# Altium schematic sheet CAN_SNIFFER.SchDoc
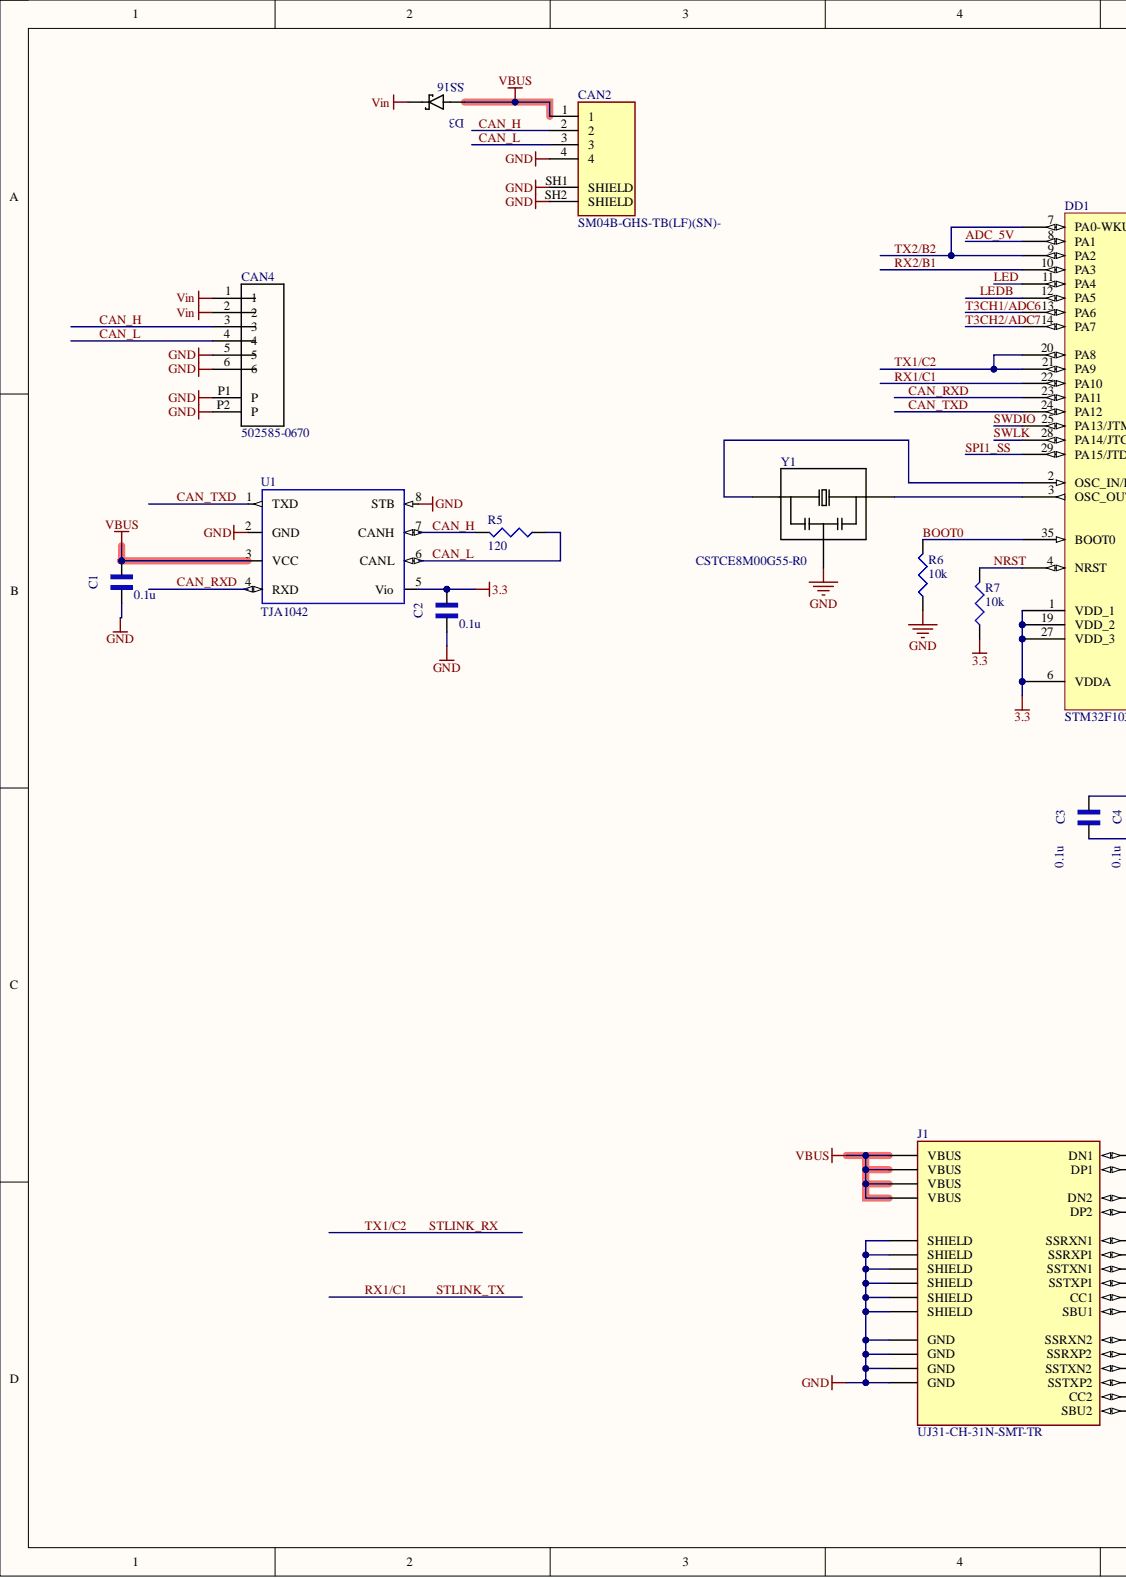
[diagram p0: CAN_SNIFFER.SchDoc, left]
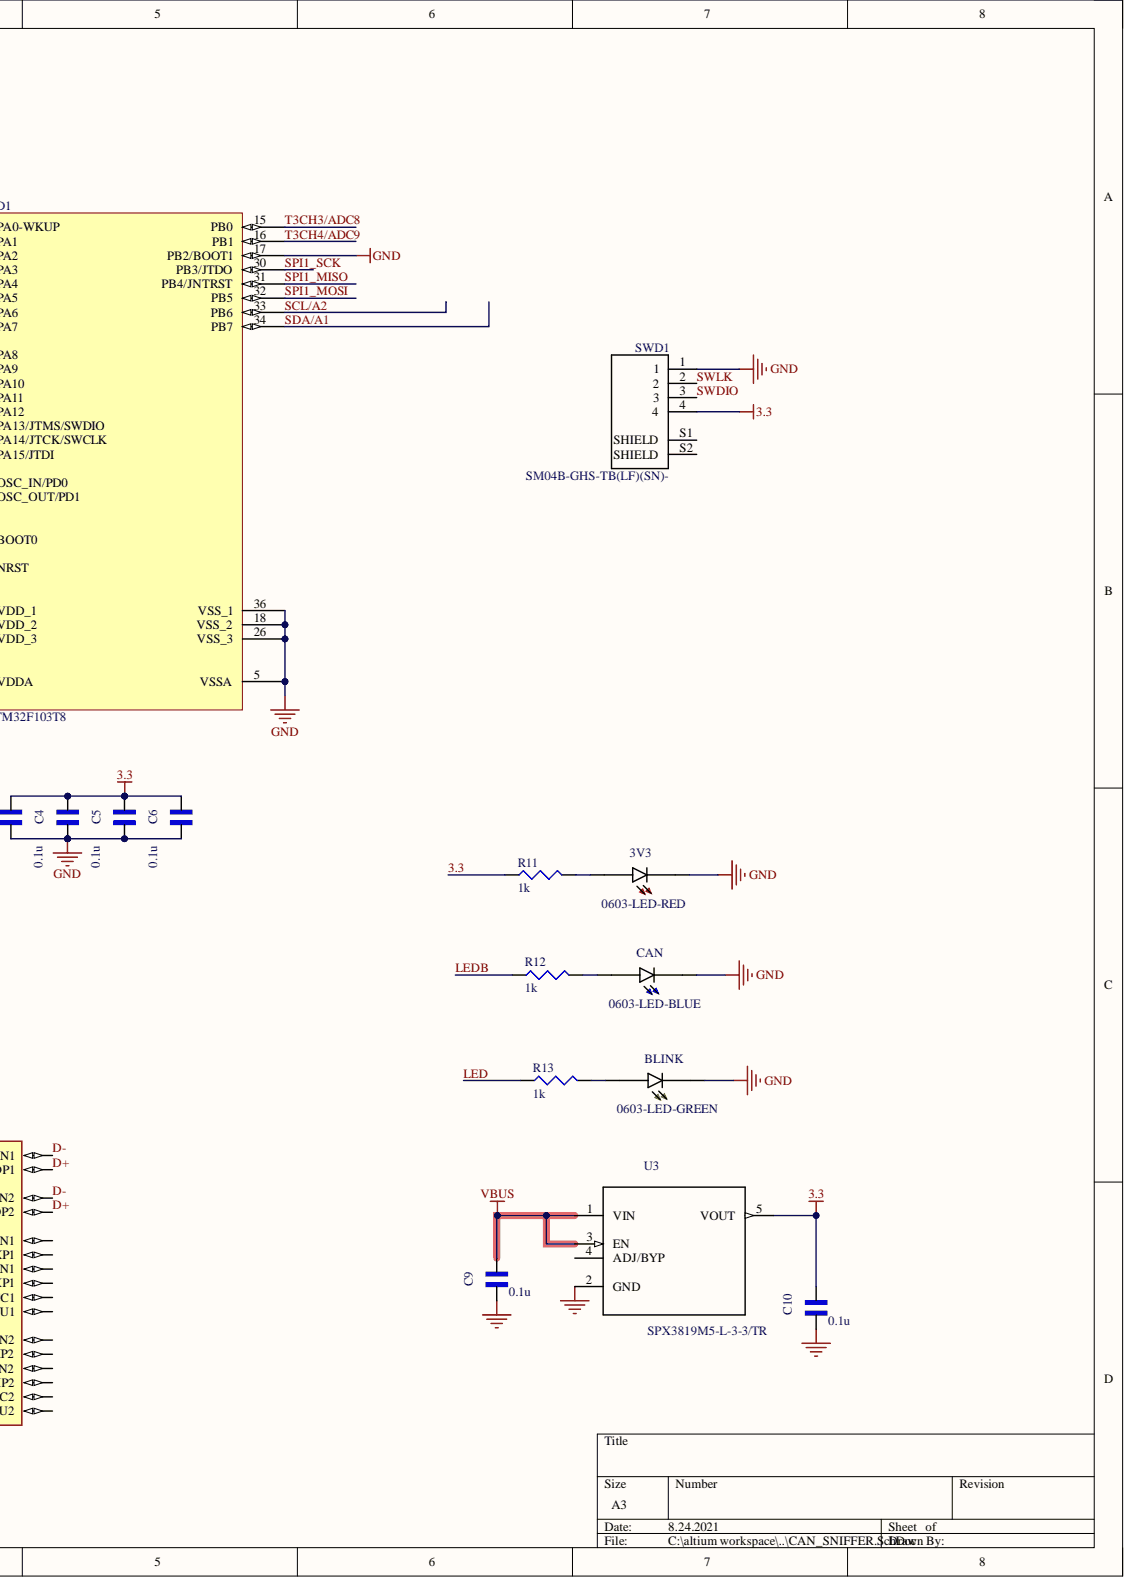
[diagram p1: CAN_SNIFFER.SchDoc, right]

## Parts
3V3  0603-LED-RED  [*LEDM1608X80_LTE-C1906R-14(Primary)]
BLINK  0603-LED-GREEN  [*LEDM1608X80_LTE-C1906R-14(Primary)]
C1  value 0.1u  [*CAPC1608X90]  (hidden comment: GX035C104MAT2-500)
C2  value 0.1u  [*CAPC1608X90]  (hidden comment: GX035C104MAT2-500)
C3  value 0.1u  [*CAPC1608X90]  (hidden comment: GX035C104MAT2-500)
C4  value 0.1u  [*CAPC1608X90]  (hidden comment: GX035C104MAT2-500)
C5  value 0.1u  [*CAPC1608X90]  (hidden comment: GX035C104MAT2-500)
C6  value 0.1u  [*CAPC1608X90]  (hidden comment: GX035C104MAT2-500)
C9  value 0.1u  [*CAPC1608X90]  (hidden comment: GX035C104MAT2-500)
C10  value 0.1u  [*CAPC1608X90]  (hidden comment: GX035C104MAT2-500)
CAN  0603-LED-BLUE  [*LEDM1608X80_LTE-C1906R-14(Primary)]
CAN2  SM04B-GHS-TB(LF)(SN)-  [JST_SM04B-GHS-TB(LF)(SN)-]
CAN4  502585-0670  [MOLEX_502585-0670]
D3  SS16  [*DIOM5227X250N_SS16(Primary)]
DD1  STM32F103T8  [*QFN50P600X600X100-37N]
J1  UJ31-CH-31N-SMT-TR  [CUI_UJ31-CH-31N-SMT-TR]
R5  value 120  [J1-0603]  (hidden comment: RC0603FR-07120RL)
R6  value 10k  [J1-0603]  (hidden comment: RC0603FR-0710KL)
R7  value 10k  [J1-0603]  (hidden comment: RC0603FR-0710KL)
R11  value 1k  [J1-0603]  (hidden comment: RC0603FR-101KL)
R12  value 1k  [J1-0603]  (hidden comment: RC0603FR-101KL)
R13  value 1k  [J1-0603]  (hidden comment: RC0603FR-101KL)
SWD1  SM04B-GHS-TB(LF)(SN)-  [JST_BM04B-GHS-holes]
U1  TJA1042  [SOT782-1]
U3  SPX3819M5-L-3-3/TR  [*SOT95P280X145-5N]
Y1  CSTCE8M00G55-R0  [*OSC_CSTCE8M00G55-R0]

## Nets
GND: 3V3.C BLINK.C C1.2 C2.2 C3.2 C4.2 C5.2 C6.2 C9.2 C10.2 CAN.C CAN2.4 CAN2.SH1(SHIELD) CAN2.SH2(SHIELD) CAN4.5 CAN4.6 CAN4.P1(P) CAN4.P2(P) DD1.5(VSSA) DD1.17(PB2/BOOT1) DD1.18(VSS_2) DD1.26(VSS_3) DD1.36(VSS_1) J1.A1(GND) J1.A12(GND) J1.B1(GND) J1.B12(GND) J1.SH1(SHIELD) J1.SH2(SHIELD) J1.SH3(SHIELD) J1.SH4(SHIELD) J1.SH5(SHIELD) J1.SH6(SHIELD) R6.1 SWD1.1 U1.2(GND) U1.8(STB) U3.2(GND) Y1.2
VBUS: C1.1 C9.1 CAN2.1 D3.A J1.A4(VBUS) J1.A9(VBUS) J1.B4(VBUS) J1.B9(VBUS) U1.3(VCC) U3.1(VIN) U3.3(EN)
Vin: CAN4.1 CAN4.2 D3.C
3.3: C2.1 C3.1 C4.1 C5.1 C6.1 C10.1 DD1.1(VDD_1) DD1.6(VDDA) DD1.19(VDD_2) DD1.27(VDD_3) R7.1 R11.1 SWD1.4 U1.5(Vio) U3.5(VOUT)
ADC_5V: DD1.8(PA1)
BOOT0: DD1.35(BOOT0) R6.2
CAN_H: CAN2.2 CAN4.3 R5.2 U1.7(CANH)
CAN_L: CAN2.3 CAN4.4 R5.1 U1.6(CANL)
CAN_RXD: DD1.23(PA11) U1.4(RXD)
CAN_TXD: DD1.24(PA12) U1.1(TXD)
D+: J1.A6(DP1) J1.B6(DP2)
D-: J1.A7(DN1) J1.B7(DN2)
LED: DD1.11(PA4) R13.1
LEDB: DD1.12(PA5) R12.1
NRST: DD1.4(NRST) R7.2
RX1/C1: DD1.22(PA10)
RX2/B1: DD1.10(PA3)
SCL/A2: DD1.33(PB6)
SDA/A1: DD1.34(PB7)
SPI1_MISO: DD1.31(PB4/JNTRST)
SPI1_MOSI: DD1.32(PB5)
SPI1_SCK: DD1.30(PB3/JTDO)
SPI1_SS: DD1.29(PA15/JTDI)
STLINK_RX: DD1.20(PA8) DD1.21(PA9)
SWDIO: DD1.25(PA13/JTMS/SWDIO) SWD1.3
SWLK: DD1.28(PA14/JTCK/SWCLK) SWD1.2
T3CH1/ADC6: DD1.13(PA6)
T3CH2/ADC7: DD1.14(PA7)
T3CH3/ADC8: DD1.15(PB0)
T3CH4/ADC9: DD1.16(PB1)
TX2/B2: DD1.7(PA0-WKUP) DD1.9(PA2)
Net3V3_A: 3V3.A R11.2
NetBLINK_A: BLINK.A R13.2
NetCAN_A: CAN.A R12.2
NetDD1_2: DD1.2(OSC_IN/PD0) Y1.1
NetDD1_3: DD1.3(OSC_OUT/PD1) Y1.3

Not connected: J1 A2(SSTXP1) A3(SSTXN1) A5(CC1) A8(SBU1) A10(SSRXN2) A11(SSRXP2) B2(SSTXP2) B3(SSTXN2) B5(CC2) B8(SBU2) B10(SSRXN1) B11(SSRXP1); SWD1 S1(SHIELD) S2(SHIELD); U3 4(ADJ/BYP)

## Library data of the parts
3V3 = 0603-LED-RED: Red 620nm LED Indication - Discrete 2.2V 0603 (1608 Metric); MP: LTST-C194KRKT or SML-D12U1WT86; Component Kind: Standard; Library Name: lib.SchLib; Package: 0603 Lite-On; SnapEDA_Link: https://www.snapeda.com/parts/LTE-C1906R-14/Lite-On%20Inc./view-part/?ref=snap; Type: SMD
BLINK = 0603-LED-GREEN: Green 571nm LED Indication - Discrete 2V 0603 (1608 Metric); MP: LTST-C191KGKT or SML-D12D1WT86 or LTST-C190KGKT; Component Kind: Standard; Library Name: lib.SchLib; Package: 0603 Lite-On; SnapEDA_Link: https://www.snapeda.com/parts/LTST-C190KGKT/Lite-On%20Inc./view-part/?ref=search&t=0603%20LED%20GREEN; Type: SMD
C1-C6 C9 C10 = GX035C104MAT2-500: Cap Thin Film 0.1uf 50v 0603; MF: AVX; MP: GX035C104MAT2-500 or CL10B104JB8NNNC; Pacage Metric: 1608; Type: SMD; Component Kind: Standard; Library Name: lib.SchLib; Package: 0603 AVX; SnapEDA_Link: https://www.snapeda.com/parts/GX035C104MAT2-500/AVX/view-part/?ref=snap
CAN = 0603-LED-BLUE: LED IrLED Chip LED 2-Pin SMD T/R; MP: LTST-S270TBKT or  LTST-C194TBKT or LTST-C191TBKT or  SML-D12D1WT86; Component Kind: Standard; Library Name: lib.SchLib; Package: 0603 Lite-On; SnapEDA_Link: https://www.snapeda.com/parts/LTE-C1906R-14/Lite-On%20Inc./view-part/?ref=snap; Type: SMD
CAN2 = SM04B-GHS-TB(LF)(SN)-: Connector Header Surface Mount, Right Angle 4 position 0.049 _1.25mm_; MF: JST Sales; ChipDip_Link: https://www.chipdip.ru/product/sm04b-ghs-tb-lf-sn-gh-1.25mm-header-side-ent; Component Kind: Standard; HelpURL: http://www.farnell.com/datasheets/2082975.pdf; Library Name: lib.SchLib; MP: SM04B-GHS-TB(LF)(SN); Package: None; SnapEDA_Link: https://www.snapeda.com/parts/SM04B-GHS-TB%28LF%29%28SN%29-/JST%20Sales%20America%20Inc./view-part/2759740/?ref=snap; System: Connector
CAN4 = 502585-0670: 1.50mm Pitch, CLIK-Mate PCB Receptacle, Single Row, Surface Mount, Right-Angle, Tin Plating, Positive Lock, 6 Circuits, Natural; MF: Molex; System: Connector; Component Kind: Standard; Library Name: lib.SchLib; MP: 502585-0670; Package: None; SnapEDA_Link: https://www.snapeda.com/parts/502494-0670/Molex/view-part/?ref=snap; Type: SMD
D3 = SS16: Diode Schottky 60V 1A Surface Mount SMA; Instructions: Do not install; Type: SMD; ChipDip_Link: https://www.chipdip.ru/product/ss16; Component Kind: Standard; Library Name: lib.SchLib; MP: SS16; Package: SMA-2; SnapEDA_Link: https://www.snapeda.com/parts/SS16/ON%20Semiconductor/view-part/?ref=search&t=ss16
DD1 = STM32F103T8: STM32F103T8U6, Микроконтроллер 32BIT ARM 64K FLASH [VFQFPN-36]; MF: STM; ChipDip_Link: https://www.chipdip.ru/product/stm32f103t8u6-2; Component Kind: Standard; HelpURL: http://www.st.com/stonline/products/literature/ds/13587.pdf; Library Name: lib.SchLib; MP: STM32F103T8U6; Package: VFQFPN-36; SnapEDA_Link: https://www.snapeda.com/parts/STM32F103T8U6TR/STMicroelectronics/view-part/?ref=search&t=STM32F103T8U6; Type: SMD
J1 = UJ31-CH-31N-SMT-TR: Type C, USB 3.1 Gen 2, 5 A, Right Angle, Surface Mount _SMT_, USB Receptacle; MF: CUI Devices; System: Connector; ChipDip_Link: https://www.chipdip.ru/product1/8002862225; Component Kind: Standard; HelpURL: https://www.chipdip.ru/product1/8002862225; Library Name: lib.SchLib; MP: UJ31-CH-31N-SMT-TR; Package: None; SnapEDA_Link: https://www.snapeda.com/parts/UJ31-CH-31N-SMT-TR/CUI%20Devices/view-part/2776725/?ref=snap; Type: SMT
R5 = RC0603FR-07120RL: 120 Ohms 1 0.1 W 1 10 W Chip Resistor 0603 1608 Metric Thick Film; MF: Yageo or NXP; Pacage Metric: 1608; ChipDip_Link: https://www.chipdip.ru/product0/9000079618; Component Kind: Standard; HelpURL: https://www.chipdip.ru/product0/9000079618; Library Name: lib.SchLib; MP: RC0603FR-07120RL; Package: R0603; Signal Integrity: Res; Simulation: RESISTOR; SnapEDA_Link: https://www.snapeda.com/parts/RC0603FR-07120RL/Yageo/view-part/?ref=search&t=RC0603FR-07120RL; Type: SMD
R6 R7 = RC0603FR-0710KL: 10 kOhms 1 0.1 W 1 10 W Chip Resistor 0603 1608 Metric Thick Film; MF: Yageo or NXP; MP: 10K 1% 0603; Pacage Metric: 1608; ChipDip_Link: https://www.chipdip.ru/product0/9000079736; Component Kind: Standard; HelpURL: https://www.chipdip.ru/product0/9000079736; Library Name: lib.SchLib; Package: R0603; Signal Integrity: Res; Simulation: RESISTOR; SnapEDA_Link: https://www.snapeda.com/parts/RC0603FR-0710KL/Yageo/view-part/?ref=dk&t=RC0603FR-0710KL&con_ref=None; Type: SMD
R11-R13 = RC0603FR-101KL: 1 kOhms ±1% 0.1W, 1/10W Chip Resistor 0603 (1608 Metric) Moisture Resistant Thick Film; MF: Yageo or NXP; Pacage Metric: 1608; Component Kind: Standard; Library Name: lib.SchLib; MP: RC0603FR-101KL; Package: R0603; Signal Integrity: Res; Simulation: RESISTOR; SnapEDA_Link: https://www.snapeda.com/parts/RC0603FR-101KL/Yageo/view-part/?ref=search&t=RC0603FR-07%201k; Type: SMD
SWD1 = SWD SM04B-GHS-TB(LF)(SN)-: Conn Shrouded Header HDR 4 POS 1.25mm Solder ST SMD Reel; Instructions: Do not install; MF: JST Sales; System: Connector; Component Kind: Standard; Library Name: lib.SchLib; MP: SM04B-GHS-TB(LF)(SN); Package: None; SnapEDA_Link: https://www.snapeda.com/parts/BM04B-GHS-TBT%28LF%29%28SN%29%28N%29/JST%20Sales%20America%20Inc./view-part/?ref=snap; Type: SMD
U1 = TJA1042: 1/1 Transceiver Half CANbus 8-SO; MF: NXP USA; ChipDip_Link: https://www.chipdip.ru/product1/8002840332; Component Kind: Standard; HelpURL: https://static.chipdip.ru/lib/583/DOC001583059.pdf; Library Name: lib.SchLib; MP: TJA1042; Package: VDFN-8 NXP USA; SnapEDA_Link: https://www.snapeda.com/parts/TJA1042/NXP%20USA%20Inc./view-part/900919/?ref=snap; Type: SMD
U3 = SPX3819M5-L-3-3/TR: Low Dropout Voltage Regulator, Adjustable 0.5A, В±1%, 2.5 to 16 Vin, 5-Pin SOT-23; MF: MaxLinear, Inc.; Value: 3V3; ChipDip_Link: https://www.chipdip.ru/product1/8003455237; Component Kind: Standard; HelpURL: http://www.farnell.com/datasheets/2614478.pdf; Library Name: lib.SchLib; MP: SPX3819M5-L-3-3/TR; Package: SOT-23-5 Exar; SnapEDA_Link: https://www.snapeda.com/parts/SPX3819M5-L/MaxLinear%2C%20Inc./view-part/?ref=snap; Type: SMD
Y1 = CSTCE8M00G55-R0: Ceramic Resonator 8MHz ??0.5% _Tol_ ??0.2% _Stability_ 33pF 40Ohm 3-Pin CSMD T/R; MF: Murata; ChipDip_Link: https://www.chipdip.ru/product1/8001783721; Component Kind: Standard; HelpURL: https://www.murata.com/en-eu/api/pdfdownloadapi?cate=&partno=CSTCE8M00G55-R0; Library Name: lib.SchLib; MP: CSTCE8M00G55-R0; Package: SMD-3 Murata; SnapEDA_Link: https://www.snapeda.com/parts/CSTCE8M00G55-R0/Murata%20Electronics%20North%20America/view-part/?ref=snap; Type: SMD
